# Altium schematic sheet Filter and output.SchDoc("Filter and output")
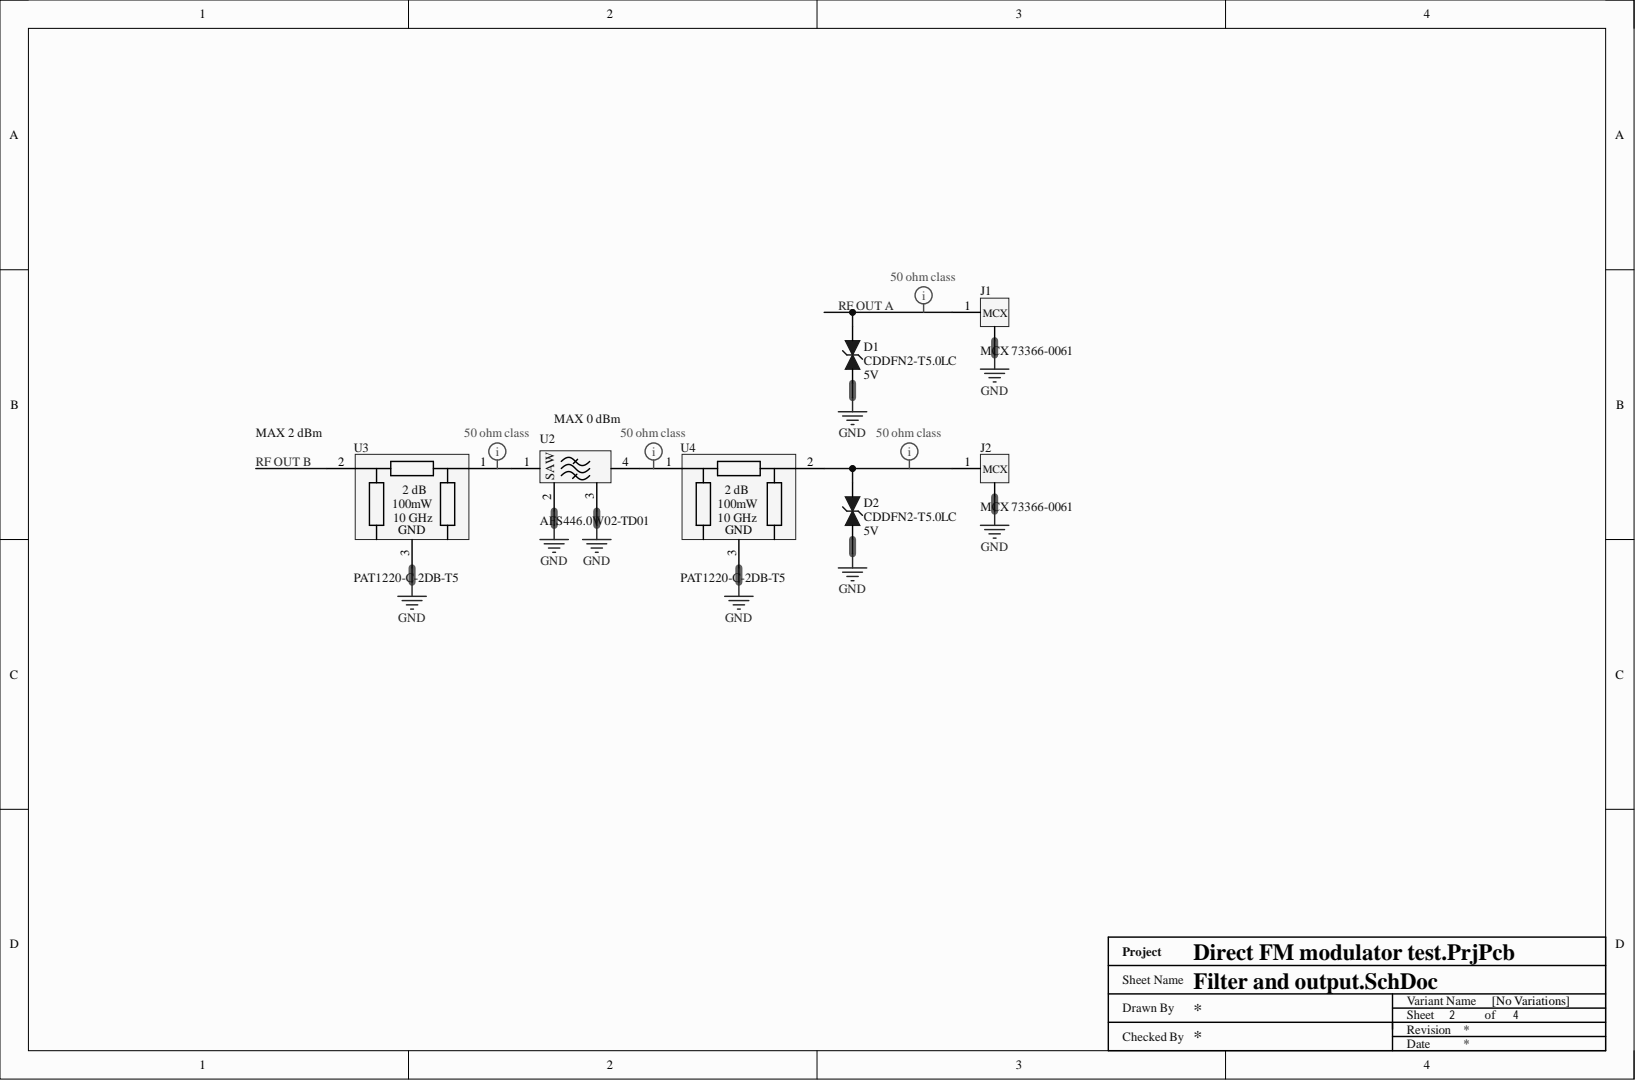
[diagram p0: Filter and output.SchDoc]

## Parts
D1  CDDFN2-T5.0LC  Operating Supply Voltage: 5V  [CDDFN2-T5.0LC]
D2  CDDFN2-T5.0LC  Operating Supply Voltage: 5V  [CDDFN2-T5.0LC]
J1  MCX 73366-0061  Connector Style: MCX  [73366-0061]
J2  MCX 73366-0061  Connector Style: MCX  [73366-0061]
U2  AFS446.0W02-TD01  [AFS446.0W02-TD01]
U3  PAT1220-C-2DB-T5  Max Power Dissipation: 100mW  [PAT1220]
U4  PAT1220-C-2DB-T5  Max Power Dissipation: 100mW  [PAT1220]

## Nets
GND: D1.2 D2.2 J1.2 J2.2 U2.2(SAW) U2.3 U3.3 U4.3
RF OUT A: D1.1 J1.1
RF OUT B: U3.2
NetD2_1: D2.1 J2.1 U4.2
NetU2_1: U2.1 U3.1
NetU2_4: U2.4 U4.1

## Library data of the parts
D1 D2 = CDDFN2-T5.0LC: TVS DIODE 5VWM 25VC DFN2; Manufacturer: Bourns; Breakdown Voltage: 7V; Capacitance: 12pF; Clamping Voltage: 15V; Diode Type: ZENER; Direction: Bidirectional; Element Configuration: Single; Leakage Current: 1uA; Max Surge Current: 5A; Number of Bidirectional Channels: 1; Power Line Protection: No; Case/Package: DFN; Category: TVS Diodes; Component Kind: Standard; ComponentLink1Description: https://datasheet.ciiva.com/6817/1758341-6817680.pdf?src-supplier=Newark; ComponentLink1URL: https://datasheet.ciiva.com/6817/1758341-6817680.pdf?src-supplier=Newark; ComponentLink2Description: https://datasheet.ciiva.com/11193/cddfn2-t5-0lc-11193904.pdf?src-supplier=Avnet; ComponentLink2URL: https://datasheet.ciiva.com/11193/cddfn2-t5-0lc-11193904.pdf?src-supplier=Avnet; ComponentLink3Description: https://datasheet.ciiva.com/4507/cddfn2-txxc-71882-4507683.pdf?src-supplier=Mouser; ComponentLink3URL: https://datasheet.ciiva.com/4507/cddfn2-txxc-71882-4507683.pdf?src-supplier=Mouser; ComponentLink4Description: https://datasheet.ciiva.com/335/cddfn2-t5-0lc-335432.pdf?src-supplier=Digi-Key; ComponentLink4URL: https://datasheet.ciiva.com/335/cddfn2-t5-0lc-335432.pdf?src-supplier=Digi-Key; ComponentLink5Description: https://datasheet.ciiva.com/335/cddfn2-t5-0lc-335433.pdf?src-supplier=Digi-Key; ComponentLink5URL: https://datasheet.ciiva.com/335/cddfn2-t5-0lc-335433.pdf?src-supplier=Digi-Key; ComponentLink6Description: https://datasheet.ciiva.com/335/cddfn2-t5-0lc-335434.pdf?src-supplier=Digi-Key; ComponentLink6URL: https://datasheet.ciiva.com/335/cddfn2-t5-0lc-335434.pdf?src-supplier=Digi-Key; Contact Plating: Gold; Length: 1mm; Library Name: Diodes.IntLib; Manufacturer Part Number: CDDFN2-T5.0LC; Max Breakdown Voltage: 7V; Max Operating Temperature: 85°C; Min Breakdown Voltage: 7V; Min Operating Temperature: -40°C; Mount: Surface Mount; Number of Channels: 1; Number of Pins: 2; Package Quantity: 1; Packaging: Tape and Reel; Polarity: Bidirectional; Pricing 1: 1=0.43000, 10=0.34500, 50=0.34500, 100=0.24100, 1000=0.15700, 10000=0.14100 (USD); Radiation Hardening: No; REACH SVHC: No SVHC; Resistor Type: Zener; Reverse Standoff Voltage: 5V; RoHS: Yes; RoHS Compliant: Yes; Series: -; Stock 1: 37803; Supplier 1: Mouser; Supplier 2: Farnell; Supplier 3: Digi-Key; Supplier 4: Arrow Electronics; Supplier Part Number 1: 652-CDDFN2-T5.0LC; Supplier Part Number 2: 1860785; Supplier Part Number 3: CDDFN2-T5.0LCCT-ND; Supplier Part Number 4: CDDFN2-T5.0LC; Termination: SMD/SMT; Working Voltage: 5V
J1 J2 = 73366-0061: CONN MCX JACK STR 50 OHM PCB; Manufacturer: Molex, LLC; Manufacturer Part Number: 0733660061; Body Finish: Gold; Body Material: Brass; Center Contact Material: Beryllium Copper; Connector Type: Jack, Female Socket; Contact Termination: Solder; Dielectric Material: Polytetrafluoroethylene (PTFE); Impedance: 50 Ohm; Mating Cycles: 500; Cable Group: -; Category: Connectors, Interconnects; Center Contact Plating: Gold; Component Kind: Standard; ComponentLink1Description: https://www.molex.com/pdm_docs/sd/733660061_sd.pdf; ComponentLink1URL: https://www.molex.com/pdm_docs/sd/733660061_sd.pdf; Fastening Type: Snap-On; Features: -; Housing Color: Gold; Includes: -; Ingress Protection: -; Insertion Loss: -; Library Name: Connectors.IntLib; Mounting Feature: -; Mounting Type: Through Hole; Operating Temperature: -55°C ~ 155°C; Packaging: Tray; Part Status: Active; Pricing 1: 1=1.95, 10=1.765, 25=1.6572, 50=1.5854, 100=1.5133, 250=1.36916, 500=1.26106, 1000=1.0809, 2500=1.00884 (USD); RoHS: RoHS Compliant; Shield Termination: Solder; Stock 1: 39394; Supplier 1: Mouser; Supplier 2: Digi-Key; Supplier 3: Farnell; Supplier Part Number 1: 538-73366-0061; Supplier Part Number 2: WM5541-ND; Supplier Part Number 3: 1909245
U2 = AFS446.0W02-TD01: Saw Filters 446MHz; Manufacturer: Abracon; Impedance: 50R; Insertion Loss: 4.5dB; Voltage Rating (DC): 10V; Category: EMI / RFI Components; Component Kind: Standard; ComponentLink1Description: https://datasheet.ciiva.com/4548/afs446-0w02-td01-43048-4548368.pdf?src-supplier=Mouser; ComponentLink1URL: https://datasheet.ciiva.com/4548/afs446-0w02-td01-43048-4548368.pdf?src-supplier=Mouser; Frequency: 446MHz; Library Name: RF components.SchLib; Manufacturer Part Number: AFS446.0W02-TD01; Max Operating Temperature: 80°C; Min Operating Temperature: -40°C; Pricing 1: 1=0.93000, 10=0.85800, 50=0.70100, 100=0.57200, 1000=0.48600, 10000=0.34600 (USD); RoHS: Yes; RoHS Compliant: Yes; Stock 1: 608; Supplier 1: Mouser; Supplier Part Number 1: 815-AFS446.0W2-TD1; Termination: Radial
U3 U4 = PAT1220-C-2DB-T5: Chip Attenuator 10GHz 2dB, 100mW; Manufacturer: Susumu; Impedance: 50R; Attenuation Value: 2dB; Case Code (Imperial): 0805; Case Code (Metric): 2012; Case/Package: 0805; Category: Uncategorized; Component Kind: Standard; ComponentLink1Description: https://datasheet.ciiva.com/15101/getdatasheetpartid-497046-15101143.pdf?src-supplier=Verical; ComponentLink1URL: https://datasheet.ciiva.com/15101/getdatasheetpartid-497046-15101143.pdf?src-supplier=Verical; ComponentLink2Description: https://datasheet.ciiva.com/2788/products-38-2788379.pdf?src-supplier=Digi-Key; ComponentLink2URL: https://datasheet.ciiva.com/2788/products-38-2788379.pdf?src-supplier=Digi-Key; ComponentLink3Description: https://datasheet.ciiva.com/24156/products-38-24156863.pdf?src-supplier=Future+Electronics; ComponentLink3URL: https://datasheet.ciiva.com/24156/products-38-24156863.pdf?src-supplier=Future+Electronics; ComponentLink4Description: https://datasheet.ciiva.com/2788/products-38-2788377.pdf?src-supplier=Digi-Key; ComponentLink4URL: https://datasheet.ciiva.com/2788/products-38-2788377.pdf?src-supplier=Digi-Key; ComponentLink5Description: https://datasheet.ciiva.com/2788/products-38-2788378.pdf?src-supplier=Digi-Key; ComponentLink5URL: https://datasheet.ciiva.com/2788/products-38-2788378.pdf?src-supplier=Digi-Key; Frequency: 10GHz; Lead Free: Lead Free; Library Name: Susumu attenuator.IntLib; Manufacturer Part Number: PAT1220-C-2DB-T5; Max Operating Temperature: 125°C; Min Operating Temperature: -55°C; Mount: Surface Mount; Number of Pins: 3; Package Quantity: 1; Packaging: Tape and Reel; Power Rating: 100mW; Pricing 1: 1=0.35000, 10=0.30800, 25=0.27800, 100=0.24300, 250=0.21300, 500=0.18800, 1000=0.14800, 5000=0.12500, 10000=0.12000 (USD); RoHS: Yes; Stock 1: 17229; Supplier 1: Mouser; Supplier Part Number 1: 754-PAT1220C-2DBT5
